# Altium schematic sheet Button.SchDoc
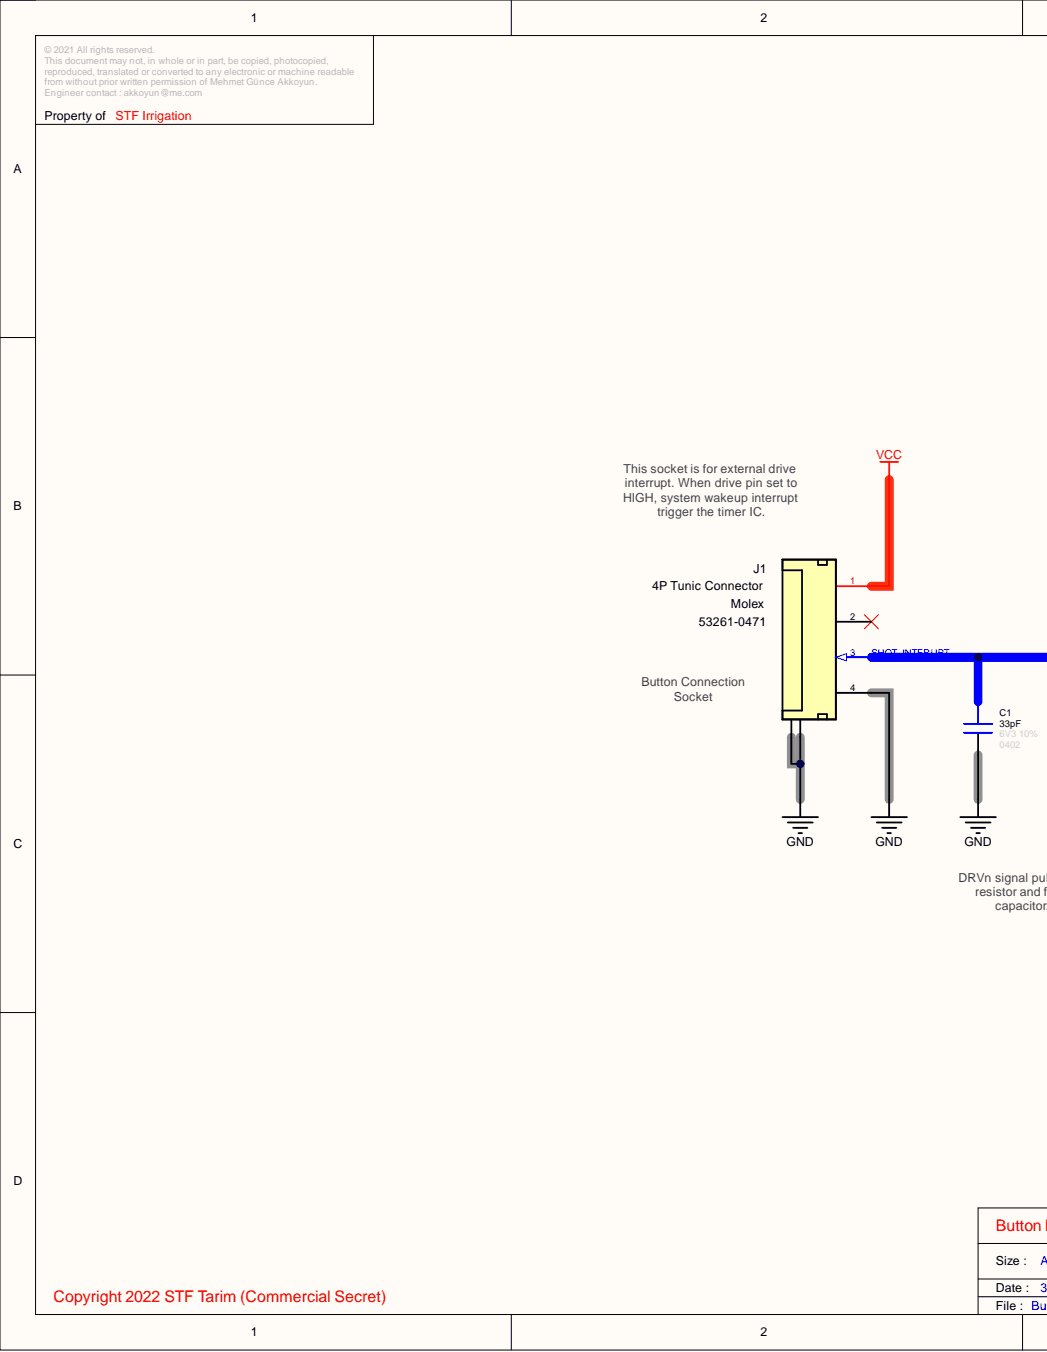
[diagram p0: Button.SchDoc, left]
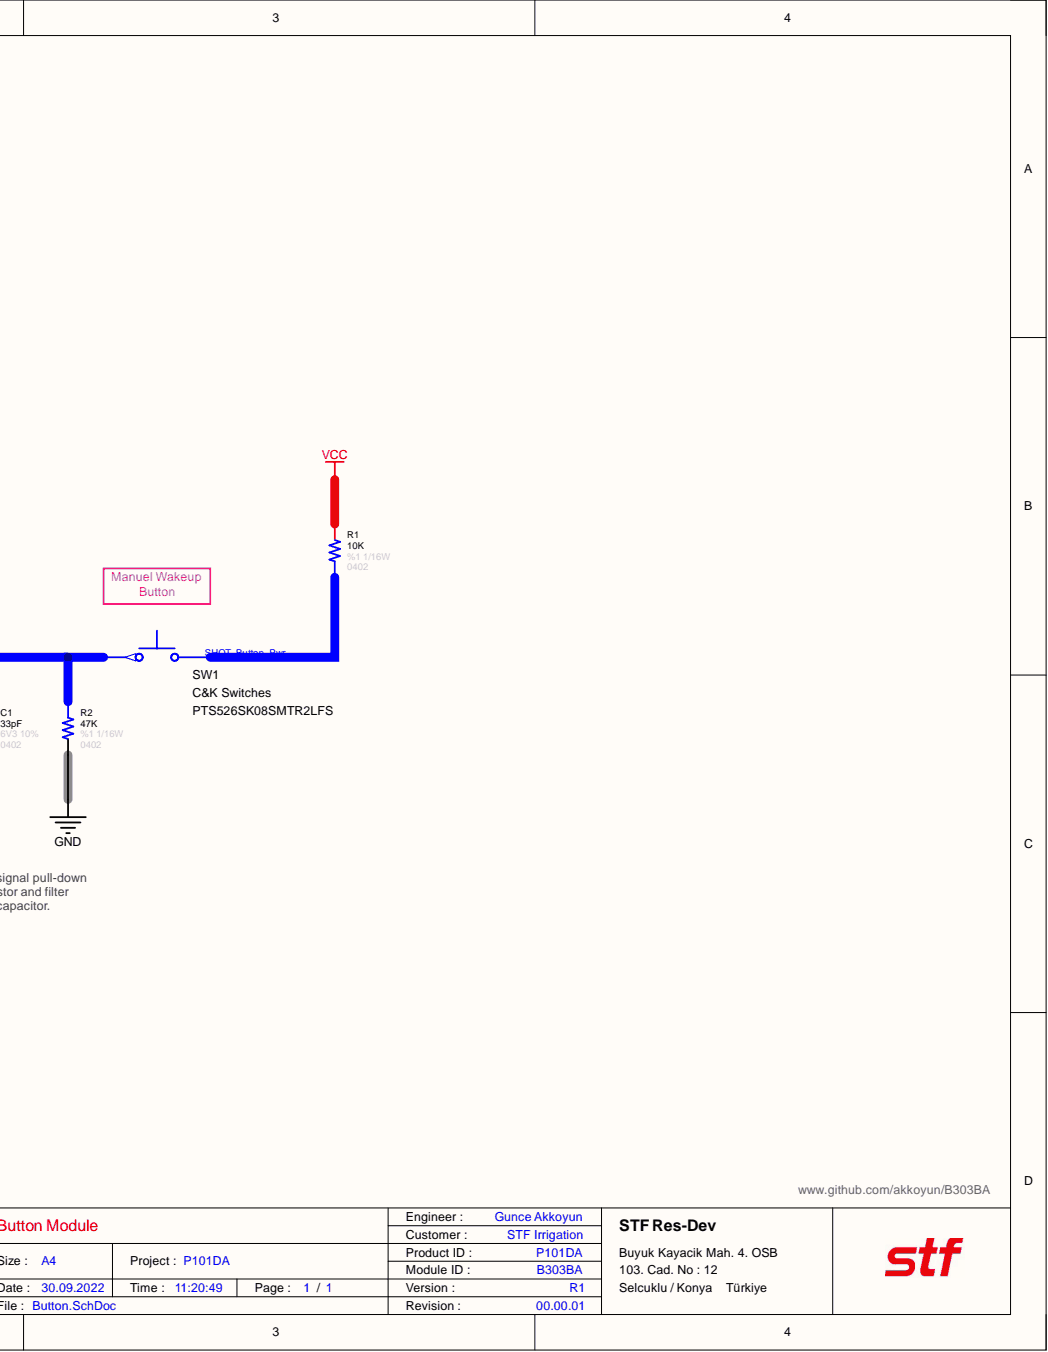
[diagram p1: Button.SchDoc, right]

## Parts
C1  value 33pF  Case: 0402  Tolerance: 10%  Voltage: 6V3  [CAPACITOR_0402]  (hidden comment: Capacitor - 33pF [0402] (Ceramic))
J1  Manufacturer Name: Molex  Manufacturer Part Number: 53261-0471  [Connector_Tunic 4P]  (hidden comment: 4P SMD Tunic Connector)
R1  value 10K  Case: 0402  Power: 1/16W  Tolerance: %1  [RESISTOR_0402]  (hidden comment: 10K - 0402 - %1 - 1/16W)
R2  value 47K  Case: 0402  Power: 1/16W  Tolerance: %1  [RESISTOR_0402]  (hidden comment: 47K - 0402 - %1 - 1/16W)
SW1  PTS526SK08SMTR2LFS  Manufacturer Name: C&K Switches  [PTS526_Switch]

## Nets
GND: C1.1 J1.4 J1.5 J1.6 R2.1
VCC: J1.1 R1.2
SHOT_Button_Pwr: R1.1 SW1.2
SHOT_INTERUPT: C1.2 J1.3 R2.2 SW1.1

Not connected: J1 2

## Library data of the parts
C1 = Capacitor - 33pF [0402-6V3]: Manufacturer Name: Kemet; Manufacturer Part Number: C0402C330J9RACAUTO; Component Kind: Standard; ComponentLink1Description: DataSheet; ComponentLink1URL: https://api.kemet.com/component-edge/download/datasheet/C0402C330J9RACAUTO.pdf; ComponentLink2Description: Mouser; ComponentLink2URL: https://www.mouser.com/Search/Refine.aspx?Keyword=80-C0402C330J9RAUTO; Description: Capacitor - 33pF [0402] (Ceramic); Library Name: P101DA_Library.IntLib
J1 = Connector - Mini Tunic 4P (1.25): Mini Tunic Connector with 4P; Pitch: 1.25mm; Position: 4; Case: SMD; Component Kind: Standard; ComponentLink1Description: DataSheet; ComponentLink1URL: https://www.mouser.com.tr/datasheet/2/276/0532610471_PCB_HEADERS-170942.pdf; ComponentLink2Description: Mouser; ComponentLink2URL: https://www.mouser.com.tr/ProductDetail/Molex/53261-0471?qs=%2Fha2pyFaduhLhIsk8tywtK7BwWtguBSP4XxyDBTOTfs%3D; Library Name: P101DA_Library.IntLib; ROHS: Yes
R1 = Resistor - 10K [0402]: Thick Film Resistors - SMD 1/16 W 10 KOhm 1%; Manufacturer Name: Vishay; Manufacturer Part Number: CRCW040210K0FKED; Component Kind: Standard; ComponentLink1Description: DataSheet; ComponentLink1URL: https://www.mouser.com.tr/datasheet/2/427/dcrcwe3-1762152.pdf; ComponentLink2Description: Mouser; ComponentLink2URL: https://www.mouser.com.tr/ProductDetail/Vishay-Dale/CRCW040210K0FKED?qs=sGAEpiMZZMu61qfTUdNhG2DpbjADlD3GcalbOv9utCY%3D; Library Name: P101DA_Library.IntLib
R2 = Resistor - 47K [0402]: Thick Film Resistors - SMD 1/16 W 47 KOhm 1%; Manufacturer Name: Vishay; Manufacturer Part Number: CRCW040247K0FKED; Component Kind: Standard; ComponentLink1Description: DataSheet; ComponentLink1URL: https://www.mouser.com.tr/datasheet/2/427/dcrcwe3-1762152.pdf; ComponentLink2Description: Mouser; ComponentLink2URL: https://www.mouser.com.tr/ProductDetail/Vishay-Dale/CRCW040247K0FKED?qs=sGAEpiMZZMu61qfTUdNhG2DpbjADlD3GL%252B3h1elTxqU%3D; Library Name: P101DA_Library.IntLib
SW1 = Switch - Push Button: Switch Tactile N.O. SPST Flush Round Button Gull Wing 0.05A 12VDC 200000Cycles 2.45N SMD T/R; Current Rating: 50 mA; Case: SMD; Component Kind: Standard; Contact Form: SPST; Library Name: P101DA_Library.IntLib; Manufacturer Part Number: PTS526SK08SMTR2LFS; Product Type: Tactile Switches; RoHS: Yes; Voltage Rating: 12 VDC
